# Altium schematic sheet IOControl.SchDoc
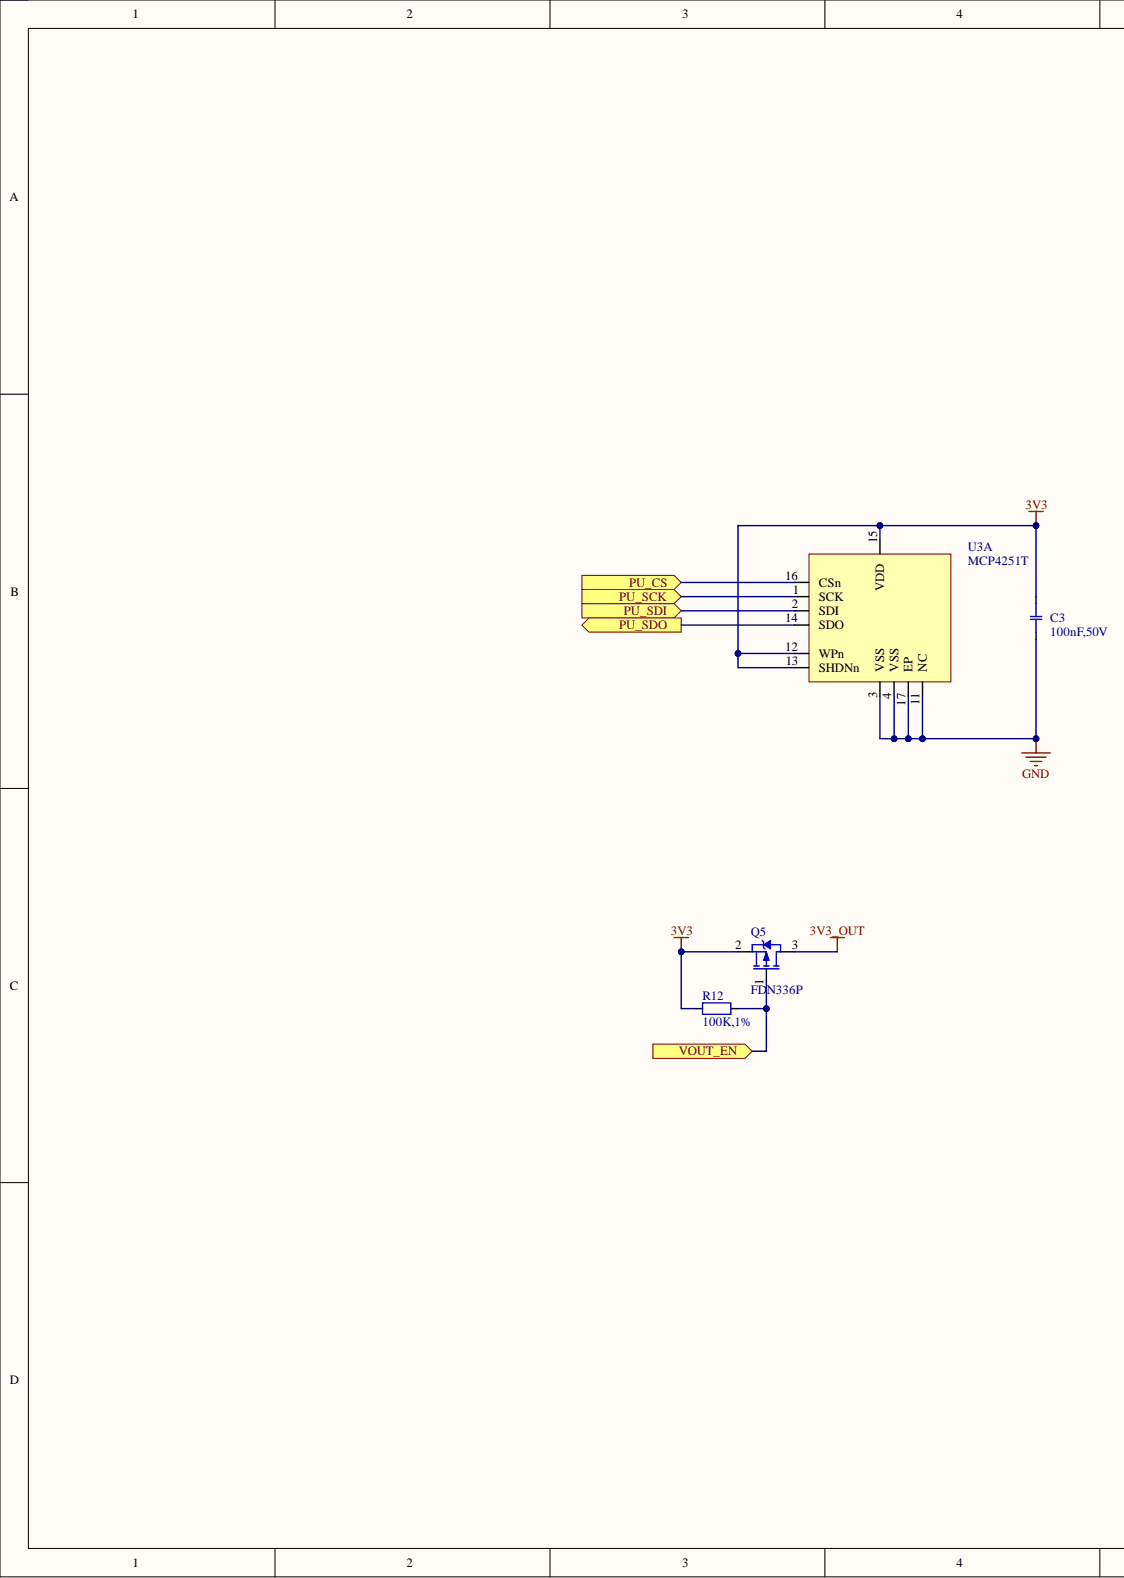
[diagram p0: IOControl.SchDoc, left]
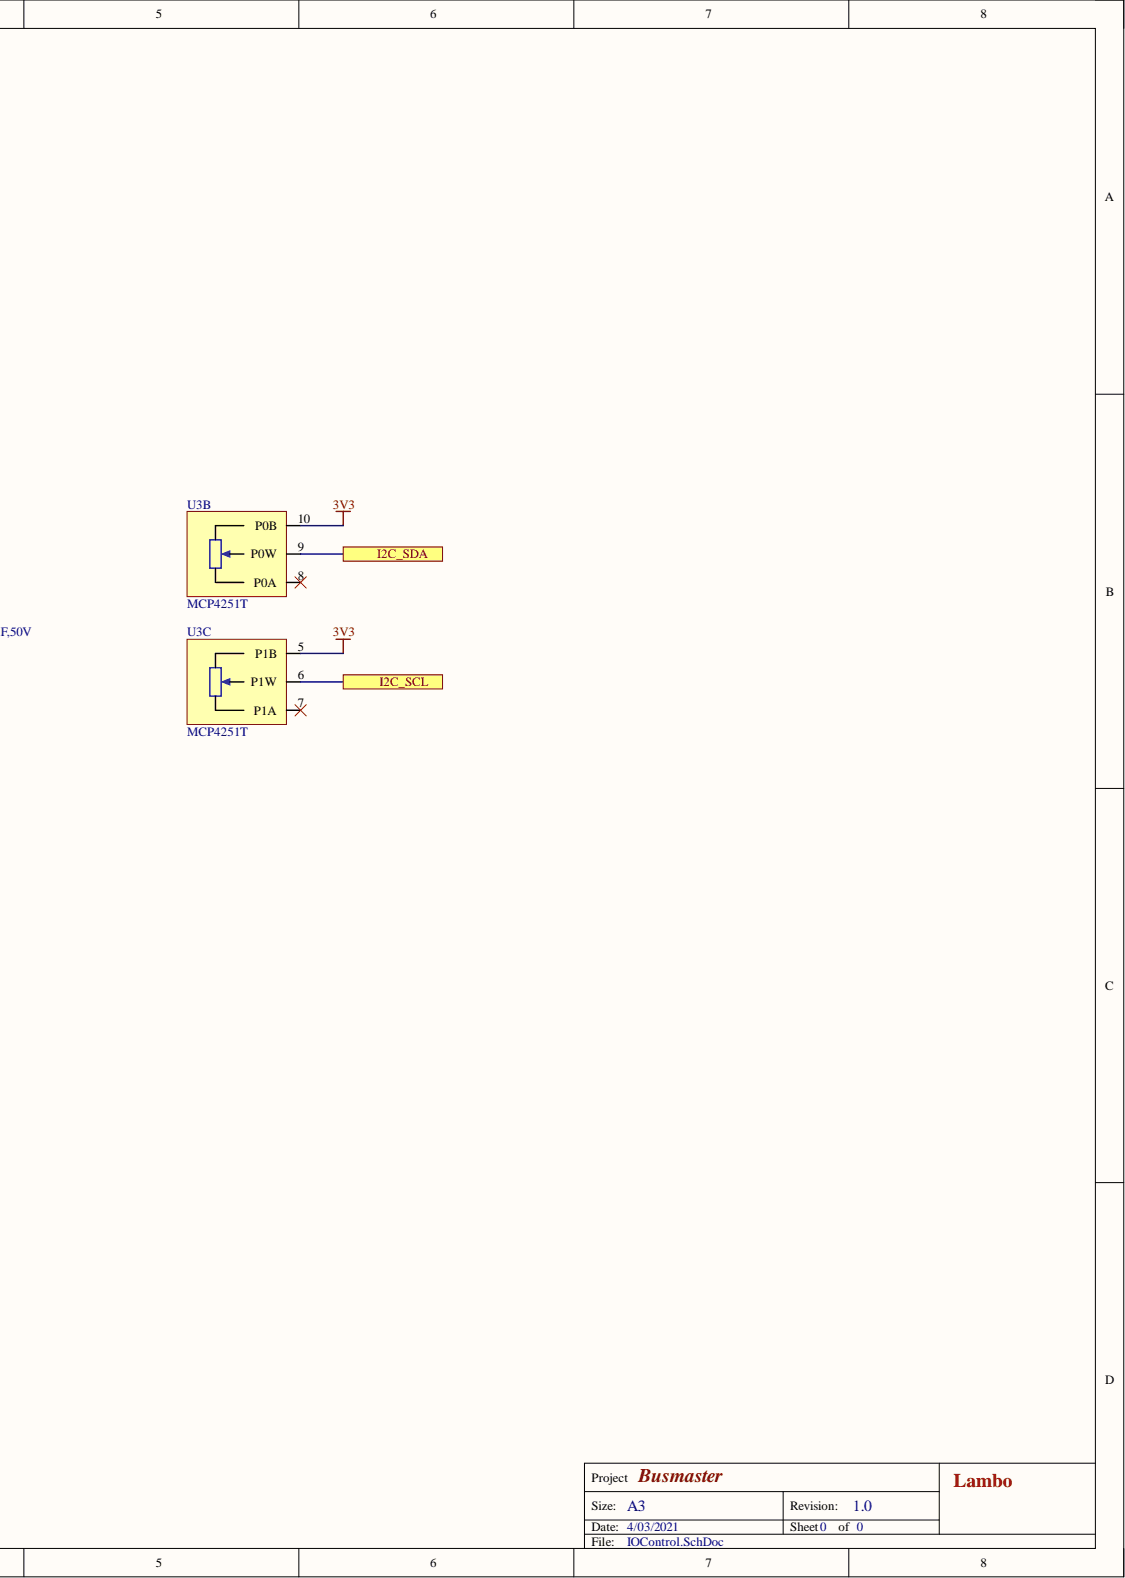
[diagram p1: IOControl.SchDoc, right]

## Parts
C3  100nF,50V  [CAPC1608X09N_0603]
Q5  FDN336P  [SOT95P230X110-3N_SOT23]
R12  100K,1%  [RESC1608X06N_0603]
U3  MCP4251T  [QFN65P400X400X90_HS-16N]  (parts A,B,C)

## Ports
I2C_SCL, I2C_SDA, PU_CS, PU_SCK, PU_SDI, PU_SDO, VOUT_EN

## Nets
3V3: C3.2 Q5.2 R12.2 U3.5(P1B) U3.10(P0B) U3.12(WPn) U3.13(SHDNn) U3.15(VDD)
3V3_OUT: Q5.3
GND: C3.1 U3.3(VSS) U3.4(VSS) U3.11(NC) U3.17(EP)
I2C_SCL: U3.6(P1W) ; port I2C_SCL
I2C_SDA: U3.9(P0W) ; port I2C_SDA
PU_CS: U3.16(CSn) ; port PU_CS
PU_SCK: U3.1(SCK) ; port PU_SCK
PU_SDI: U3.2(SDI) ; port PU_SDI
PU_SDO: U3.14(SDO) ; port PU_SDO
VOUT_EN: Q5.1 R12.1 ; port VOUT_EN

Not connected: U3 7(P1A) 8(P0A)

## Library data of the parts
C3 = CCH-104CH-G: CAP CER 0.1UF 50V 10% X7R 0603; Manufacturer: Kemet; Manufacturer Part Number: C0603C104K5RACTU; Database Table Name: c_capacitor; Schematic Symbol: CAP-NP_1; Type: Ceramic; BWA PN: CCH-104CH-G; Capacitance: 100nF; Component Kind: Standard; Dielectric: X7R; Display_Name: 100nF,50V; Library Name: library.Dblib; Supplier 1: Digi-Key; Supplier Part Number 1: 399-5089-1-ND; Tolerance: 10%; Voltage: 50V
Q5 = TRN-10046-A: MOSFET P-Channel; Manufacturer: Fairchild Semiconductor; Database Table Name: q_mosfet; Id(max): 1.3A; rds(on): 200 mOhm @ 1.3A, 4.5V; Schematic Symbol: MOSFET-P_1; Type: P; Vds(max): 20V; Vgs(max): +/-8V; Vth(max): 1.5V @ 250µA; BWA PN: TRN-10046-A; Component Kind: Standard; Display_Name: FDN336P; Library Name: library.Dblib; Manufacturer Part Number: FDN336P; Supplier 1: Digi-Key; Supplier Part Number 1: FDN336PCT-ND
R12 = RSH-100K0-D: RES 100K OHM 1/10W 1% 0603 SMD; Manufacturer: Yageo; Manufacturer Part Number: RC0603FR-07100KL; Database Table Name: r_resistor; Power: 0.1W; Schematic Symbol: RESISTOR_1; BWA PN: RSH-100K0-D; Component Kind: Standard; Display_Name: 100K,1%; Library Name: library.Dblib; Resistance: 100K; Supplier 1: Digi-Key; Supplier Part Number 1: 311-100KHRCT-ND; Tolerance: 1%; Type: Chip
U3 = ICT-10921-A: IC DGTL POT 10KOHM 257TAP 16QFN; Manufacturer: Microchip Technology; Manufacturer Part Number: MCP4251T-103E/ML; Database Table Name: u_integrated_circuit; Schematic Symbol: MCP4241T-103E; Temp Range: -40°C ~ 125°C; BWA PN: ICT-10921-A; Component Kind: Standard; Display_Name: MCP4251T; Library Name: library.Dblib; Supplier 1: Digi-Key; Supplier Part Number 1: MCP4251T-103E/MLCT-ND
